# Altium schematic sheet HS40-1177-B09-BUTTON BOARD.SchDoc
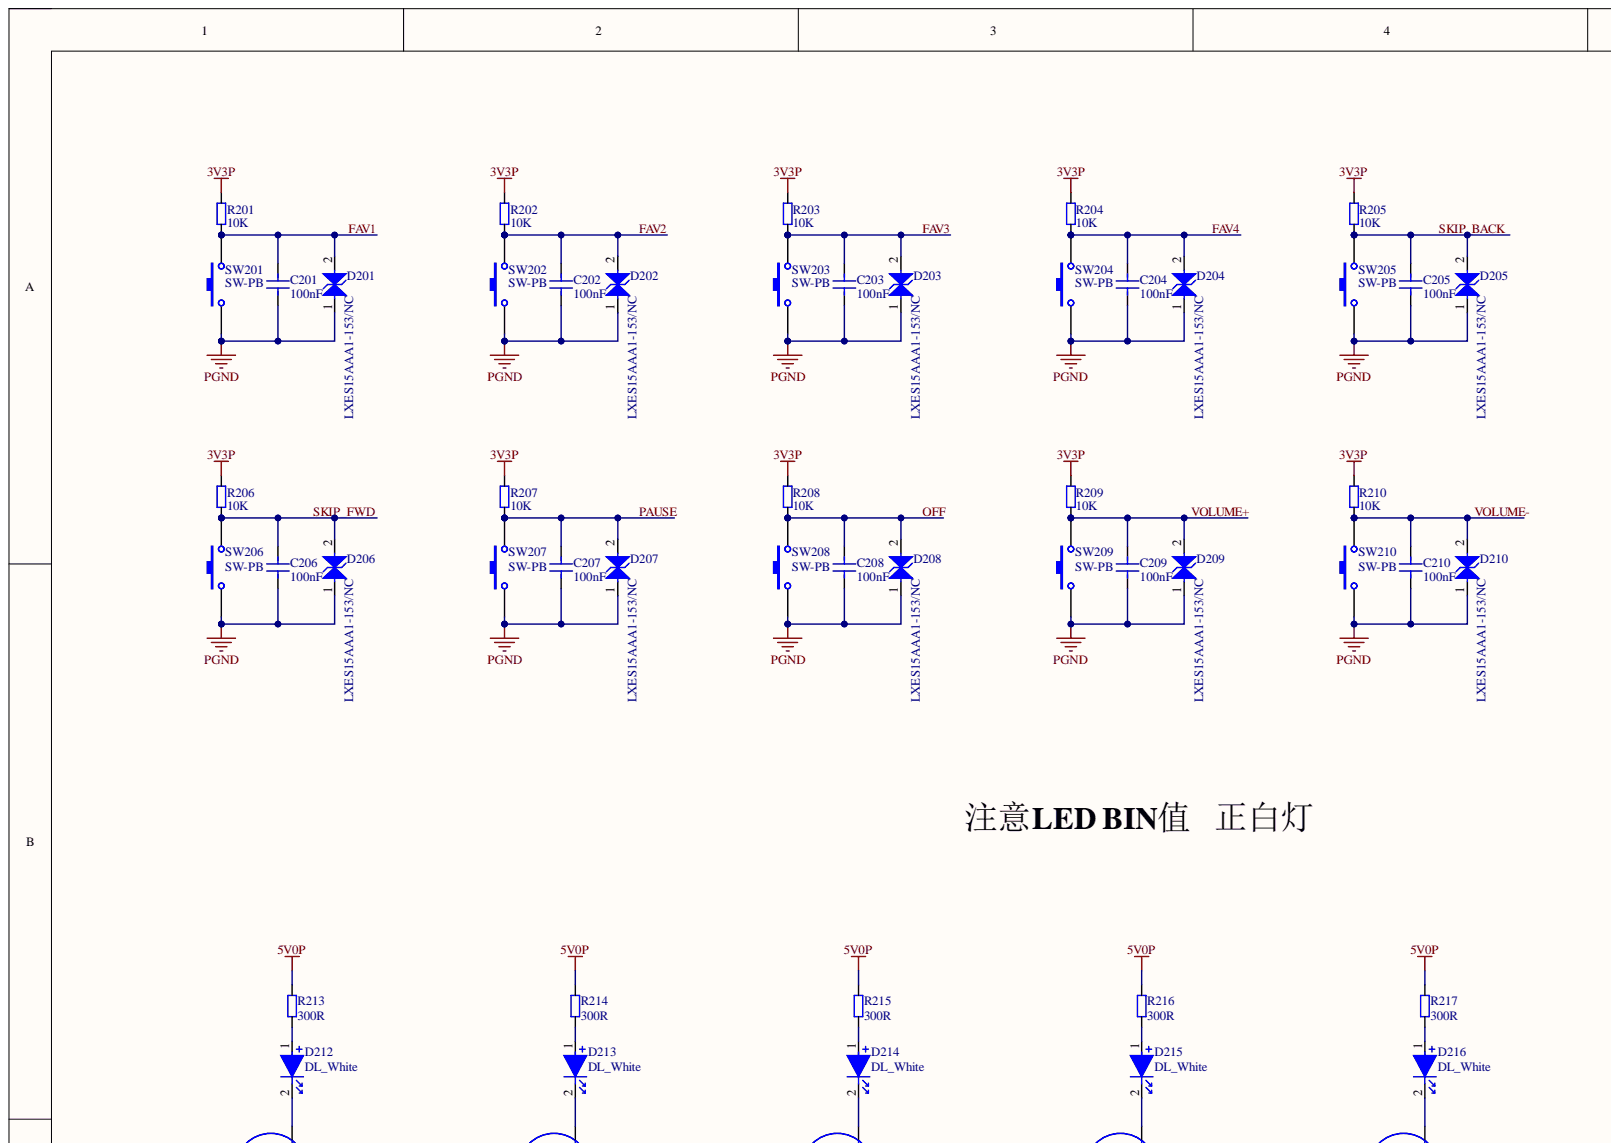
[diagram p0: HS40-1177-B09-BUTTON BOARD.SchDoc, top left]
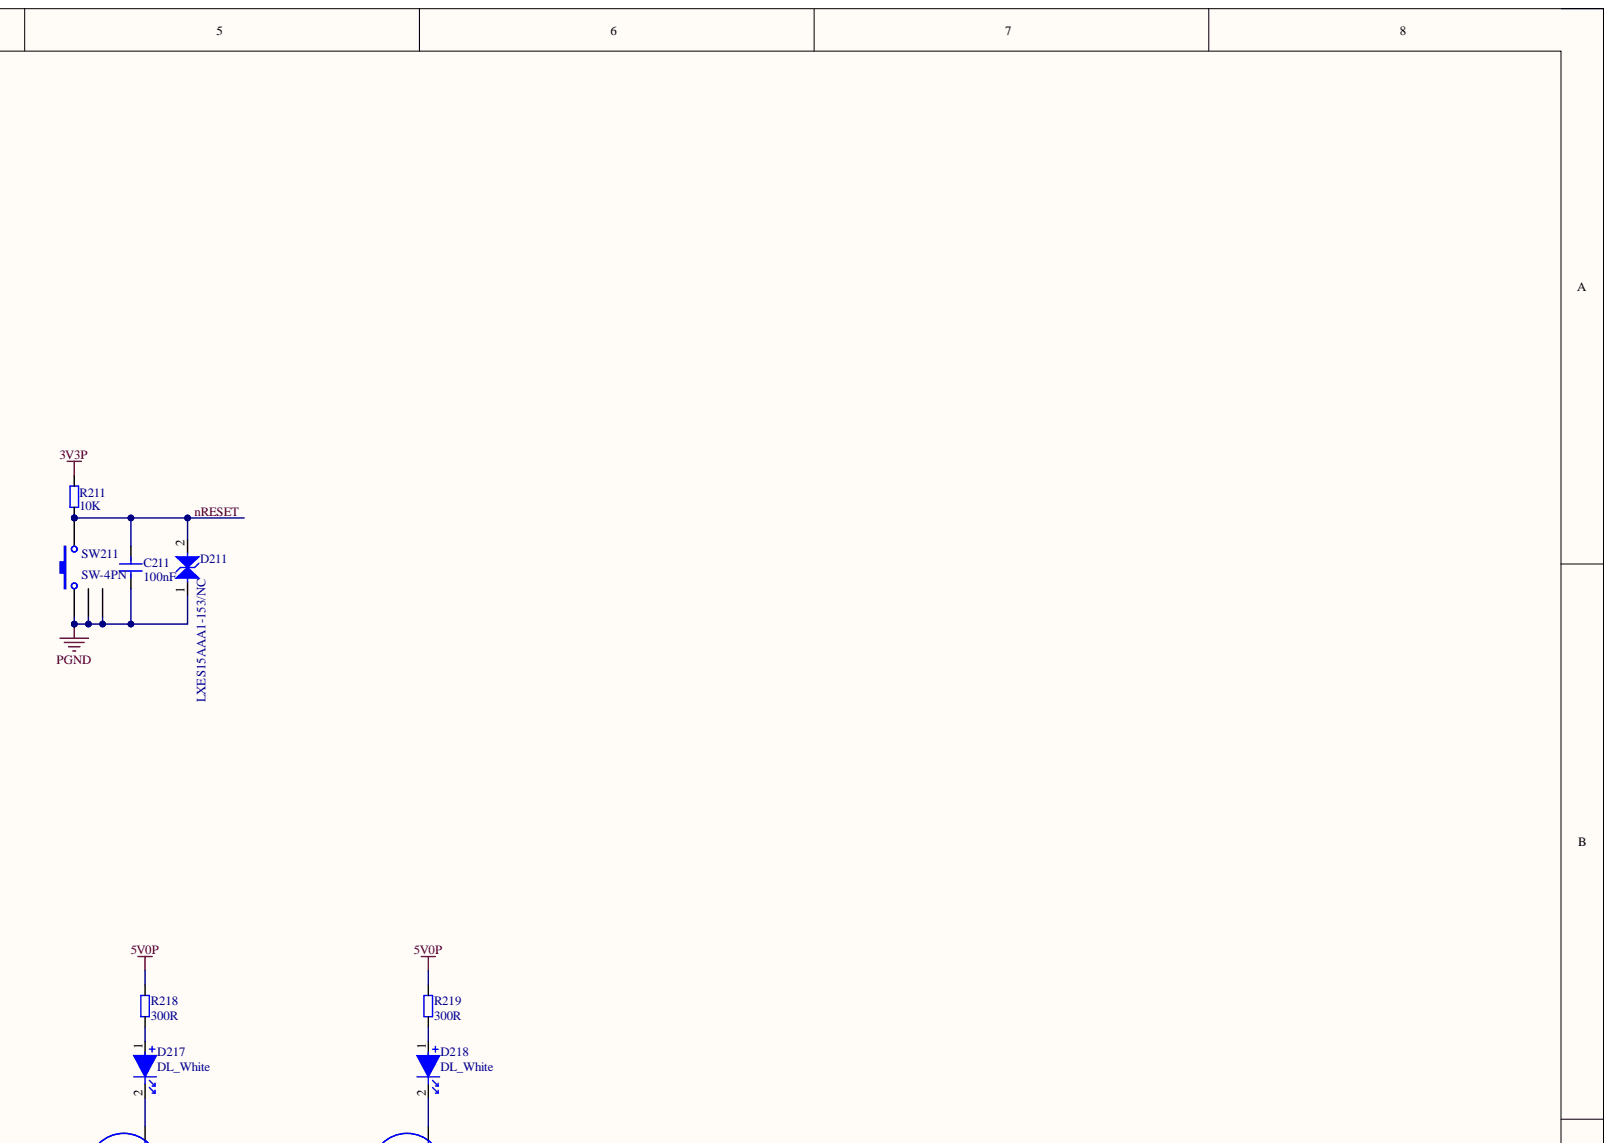
[diagram p1: HS40-1177-B09-BUTTON BOARD.SchDoc, top right]
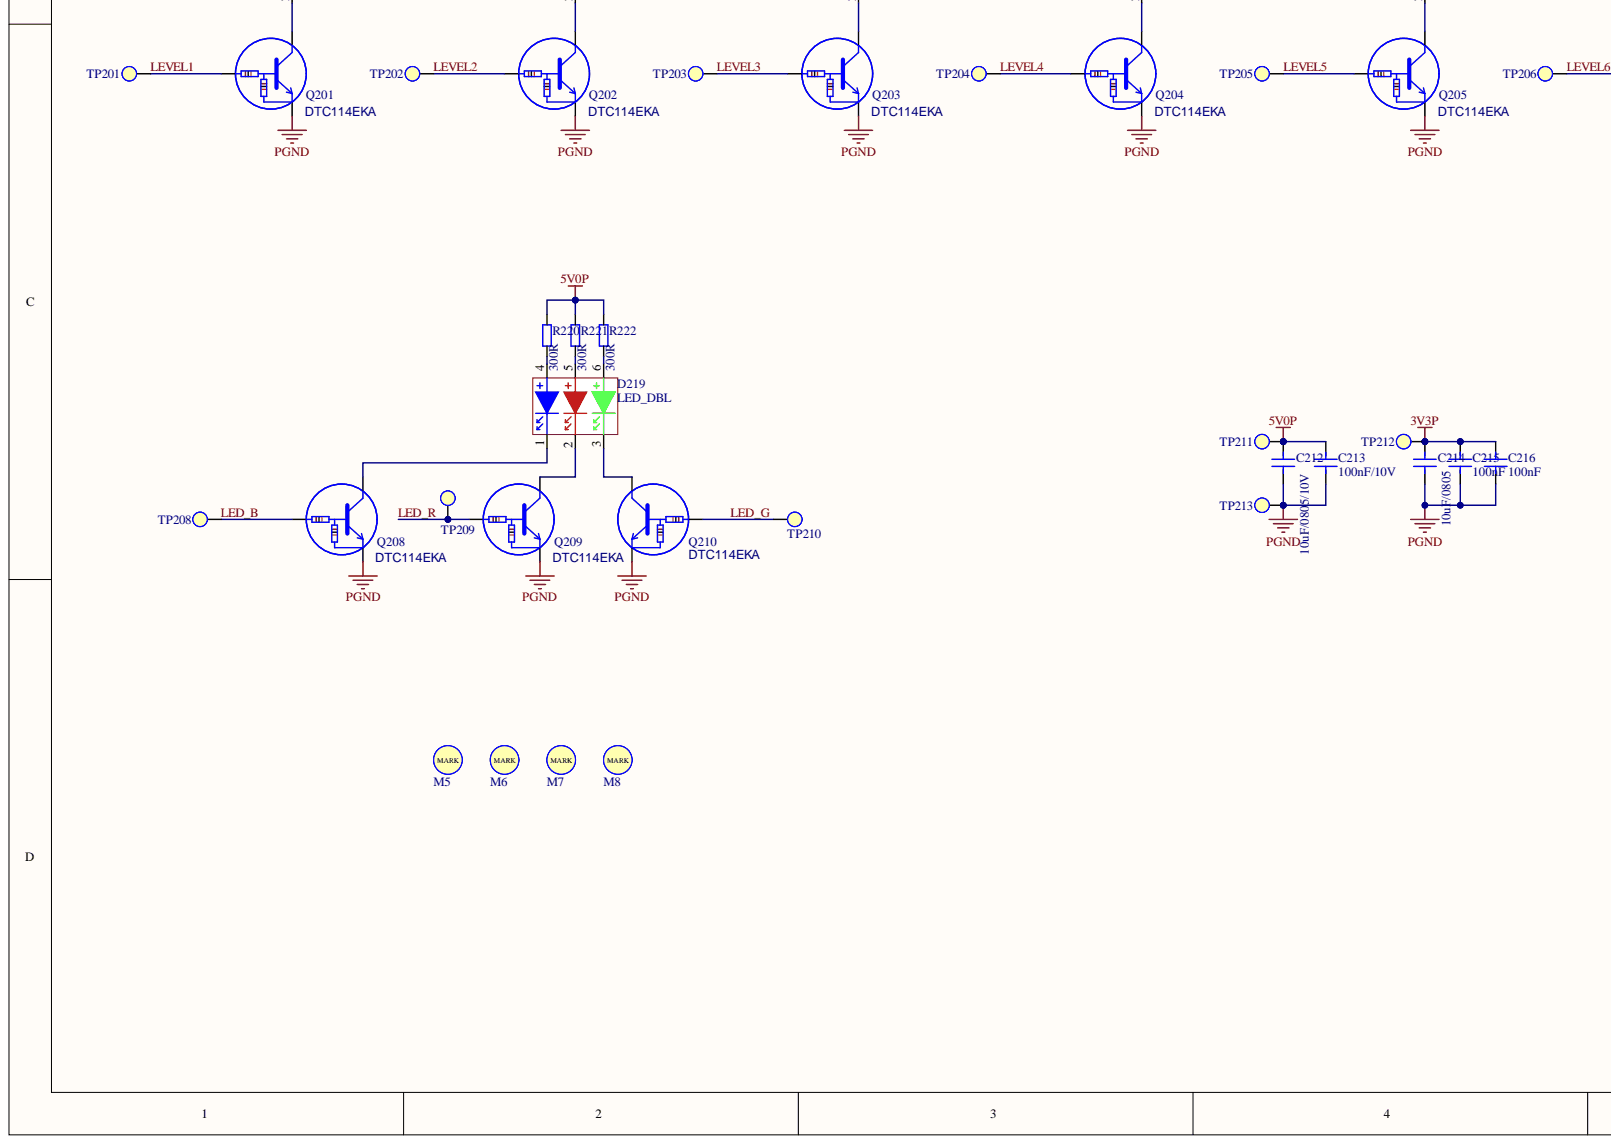
[diagram p2: HS40-1177-B09-BUTTON BOARD.SchDoc, bottom left]
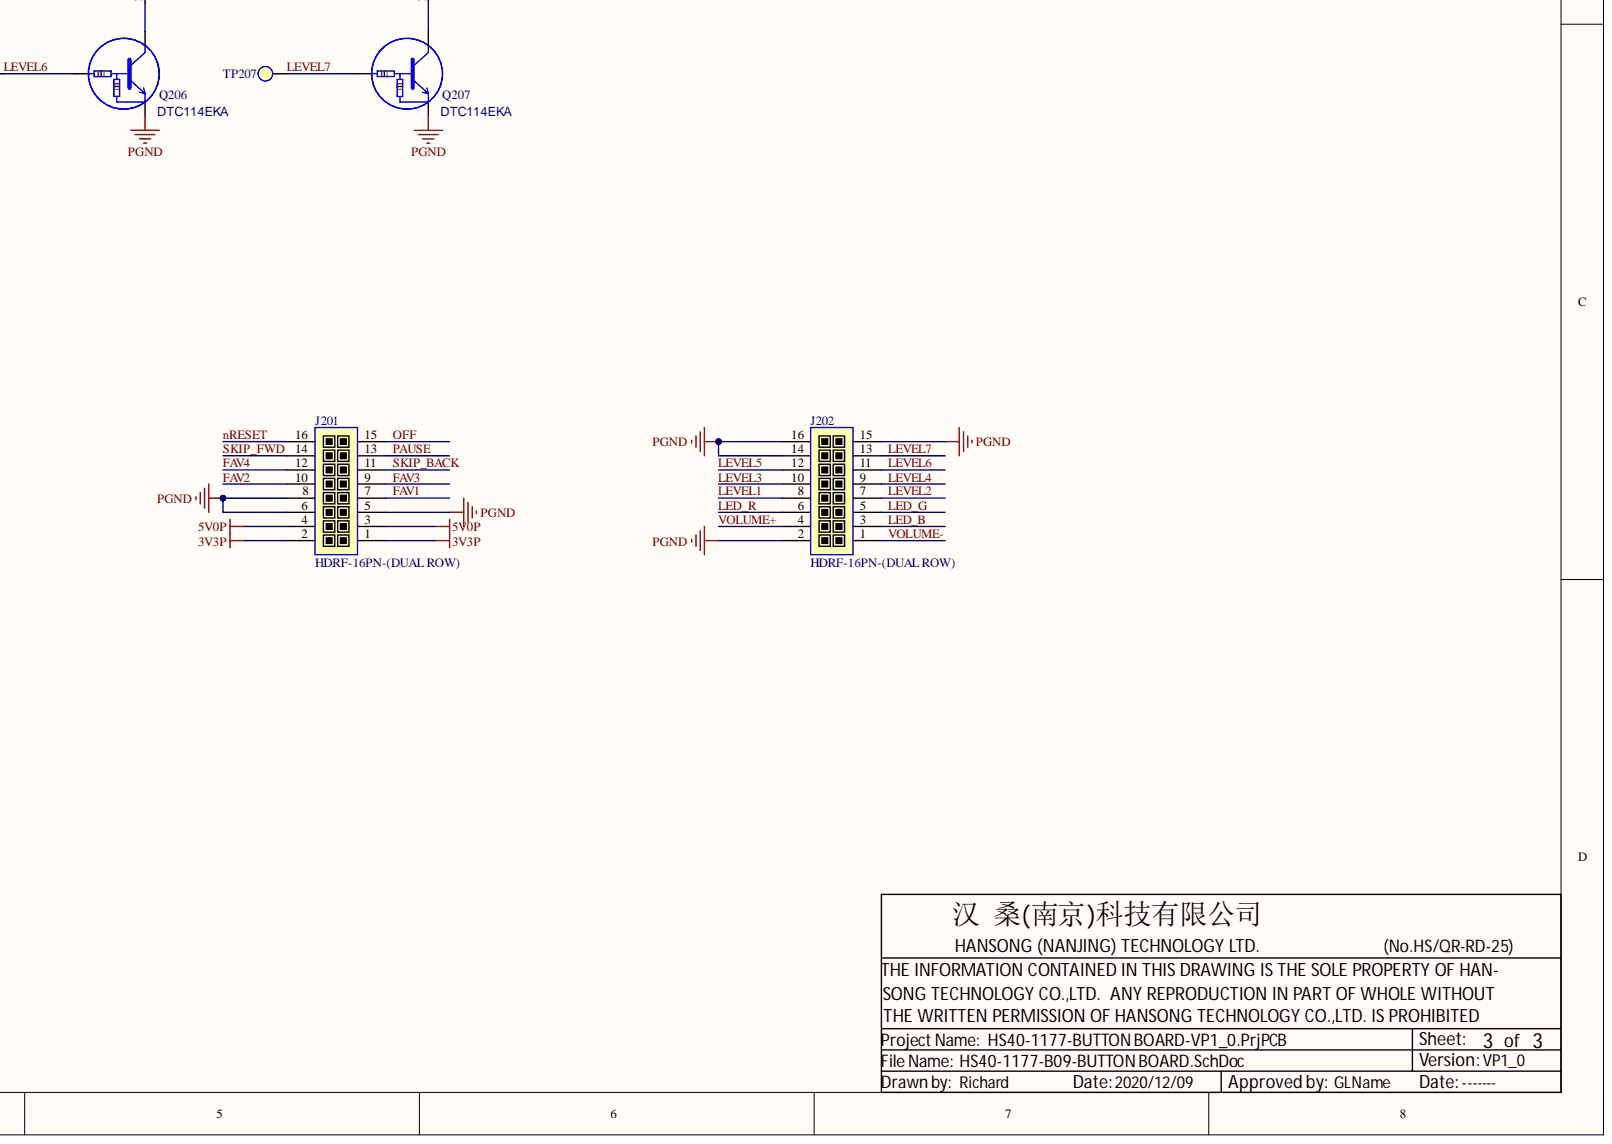
[diagram p3: HS40-1177-B09-BUTTON BOARD.SchDoc, bottom right]

## Parts
C201  100nF  [CC-0402]
C202  100nF  [CC-0402]
C203  100nF  [CC-0402]
C204  100nF  [CC-0402]
C205  100nF  [CC-0402]
C206  100nF  [CC-0402]
C207  100nF  [CC-0402]
C208  100nF  [CC-0402]
C209  100nF  [CC-0402]
C210  100nF  [CC-0402]
C211  100nF  [CC-0402]
C212  10uF/0805/10V  [CC-0805]
C213  100nF/10V  [CC-0402]
C214  10uF/0805  [CC-0805]
C215  100nF  [CC-0402]
C216  100nF  [CC-0402]
D201  LXES15AAA1-153/NC  [DZ-2PN-(0402,TVS)]
D202  LXES15AAA1-153/NC  [DZ-2PN-(0402,TVS)]
D203  LXES15AAA1-153/NC  [DZ-2PN-(0402,TVS)]
D204  LXES15AAA1-153/NC  [DZ-2PN-(0402,TVS)]
D205  LXES15AAA1-153/NC  [DZ-2PN-(0402,TVS)]
D206  LXES15AAA1-153/NC  [DZ-2PN-(0402,TVS)]
D207  LXES15AAA1-153/NC  [DZ-2PN-(0402,TVS)]
D208  LXES15AAA1-153/NC  [DZ-2PN-(0402,TVS)]
D209  LXES15AAA1-153/NC  [DZ-2PN-(0402,TVS)]
D210  LXES15AAA1-153/NC  [DZ-2PN-(0402,TVS)]
D211  LXES15AAA1-153/NC  [DZ-2PN-(0402,TVS)]
D212  DL_White  [DL-2PN-1.6X0.8X0.6-(0603)]
D213  DL_White  [DL-2PN-1.6X0.8X0.6-(0603)]
D214  DL_White  [DL-2PN-1.6X0.8X0.6-(0603)]
D215  DL_White  [DL-2PN-1.6X0.8X0.6-(0603)]
D216  DL_White  [DL-2PN-1.6X0.8X0.6-(0603)]
D217  DL_White  [DL-2PN-1.6X0.8X0.6-(0603)]
D218  DL_White  [DL-2PN-1.6X0.8X0.6-(0603)]
D219  LED_DBL  [DL-6PN-1.6X1.6X0.4-(THREE COLOR)]
J201  HDRF-16PN-(DUAL ROW)  [HDRF-16PN-1.27PH-10.51X3.1X4.6-(POST,DUAL ROW,43-1-000403)]
J202  HDRF-16PN-(DUAL ROW)  [HDRF-16PN-1.27PH-10.51X3.1X4.6-(POST,DUAL ROW,43-1-000403)]
M5  MARK  [MARK-(ROUND,MULTI  LAYER)]
M6  MARK  [MARK-(ROUND,MULTI  LAYER)]
M7  MARK  [MARK-(ROUND,MULTI  LAYER)]
M8  MARK  [MARK-(ROUND,MULTI  LAYER)]
Q201  DTC114EKA  [TS-3PN-2.9X1.3X1.1-(SOT23,B,C,E)]
Q202  DTC114EKA  [TS-3PN-2.9X1.3X1.1-(SOT23,B,C,E)]
Q203  DTC114EKA  [TS-3PN-2.9X1.3X1.1-(SOT23,B,C,E)]
Q204  DTC114EKA  [TS-3PN-2.9X1.3X1.1-(SOT23,B,C,E)]
Q205  DTC114EKA  [TS-3PN-2.9X1.3X1.1-(SOT23,B,C,E)]
Q206  DTC114EKA  [TS-3PN-2.9X1.3X1.1-(SOT23,B,C,E)]
Q207  DTC114EKA  [TS-3PN-2.9X1.3X1.1-(SOT23,B,C,E)]
Q208  DTC114EKA  [TS-3PN-2.9X1.3X1.1-(SOT23,B,C,E)]
Q209  DTC114EKA  [TS-3PN-2.9X1.3X1.1-(SOT23,B,C,E)]
Q210  DTC114EKA  [TS-3PN-2.9X1.3X1.1-(SOT23,B,C,E)]
R201  10K  [RR-0402]
R202  10K  [RR-0402]
R203  10K  [RR-0402]
R204  10K  [RR-0402]
R205  10K  [RR-0402]
R206  10K  [RR-0402]
R207  10K  [RR-0402]
R208  10K  [RR-0402]
R209  10K  [RR-0402]
R210  10K  [RR-0402]
R211  10K  [RR-0402]
R213  300R  [RR-0603]
R214  300R  [RR-0603]
R215  300R  [RR-0603]
R216  300R  [RR-0603]
R217  300R  [RR-0603]
R218  300R  [RR-0603]
R219  300R  [RR-0603]
R220  300R  [RR-0603]
R221  300R  [RR-0603]
R222  300R  [RR-0603]
SW201  SW-PB  [SW-2PN-3.9X2.9XH-(IT-1100E-1160,25-1-000009,NO DATASHEET)]
SW202  SW-PB  [SW-2PN-3.9X2.9XH-(IT-1100E-1160,25-1-000009,NO DATASHEET)]
SW203  SW-PB  [SW-2PN-3.9X2.9XH-(IT-1100E-1160,25-1-000009,NO DATASHEET)]
SW204  SW-PB  [SW-2PN-3.9X2.9XH-(IT-1100E-1160,25-1-000009,NO DATASHEET)]
SW205  SW-PB  [SW-2PN-3.9X2.9XH-(IT-1100E-1160,25-1-000009,NO DATASHEET)]
SW206  SW-PB  [SW-2PN-3.9X2.9XH-(IT-1100E-1160,25-1-000009,NO DATASHEET)]
SW207  SW-PB  [SW-2PN-3.9X2.9XH-(IT-1100E-1160,25-1-000009,NO DATASHEET)]
SW208  SW-PB  [SW-2PN-3.9X2.9XH-(IT-1100E-1160,25-1-000009,NO DATASHEET)]
SW209  SW-PB  [SW-2PN-3.9X2.9XH-(IT-1100E-1160,25-1-000009,NO DATASHEET)]
SW210  SW-PB  [SW-2PN-3.9X2.9XH-(IT-1100E-1160,25-1-000009,NO DATASHEET)]
SW211  SW-4PN  [SW-4PN-7.3X6X3.95-(TAC-32N-V-T/R,25-1-000015)]
TP201  TP  [TP-D0.8]
TP202  TP  [TP-D0.8]
TP203  TP  [TP-D0.8]
TP204  TP  [TP-D0.8]
TP205  TP  [TP-D0.8]
TP206  TP  [TP-D0.8]
TP207  TP  [TP-D0.8]
TP208  TP  [TP-D0.8]
TP209  TP  [TP-D0.8]
TP210  TP  [TP-D0.8]
TP211  TP  [TP-D0.8]
TP212  TP  [TP-D0.8]
TP213  TP  [TP-D0.8]

## Nets
3V3P: C214.2 C215.2 C216.2 J201.1 J201.2 R201.2 R202.2 R203.2 R204.2 R205.2 R206.2 R207.2 R208.2 R209.2 R210.2 R211.2 TP212.1
5V0P: C212.2 C213.2 J201.3 J201.4 R213.2 R214.2 R215.2 R216.2 R217.2 R218.2 R219.2 R220.2 R221.2 R222.2 TP211.1
PGND: C201.1 C202.1 C203.1 C204.1 C205.1 C206.1 C207.1 C208.1 C209.1 C210.1 C211.1 C212.1 C213.1 C214.1 C215.1 C216.1 D201.1 D202.1 D203.1 D204.1 D205.1 D206.1 D207.1 D208.1 D209.1 D210.1 D211.1 J201.5 J201.6 J201.8 J202.2 J202.14 J202.15 J202.16 Q201.e Q202.e Q203.e Q204.e Q205.e Q206.e Q207.e Q208.e Q209.e Q210.e SW201.1 SW202.1 SW203.1 SW204.1 SW205.1 SW206.1 SW207.1 SW208.1 SW209.1 SW210.1 SW211.1 SW211.3 SW211.4 TP213.1
FAV1: C201.2 D201.2 J201.7 R201.1 SW201.2
FAV2: C202.2 D202.2 J201.10 R202.1 SW202.2
FAV3: C203.2 D203.2 J201.9 R203.1 SW203.2
FAV4: C204.2 D204.2 J201.12 R204.1 SW204.2
LED_B: J202.3 Q208.b TP208.1
LED_G: J202.5 Q210.b TP210.1
LED_R: J202.6 Q209.b TP209.1
LEVEL1: J202.8 Q201.b TP201.1
LEVEL2: J202.7 Q202.b TP202.1
LEVEL3: J202.10 Q203.b TP203.1
LEVEL4: J202.9 Q204.b TP204.1
LEVEL5: J202.12 Q205.b TP205.1
LEVEL6: J202.11 Q206.b TP206.1
LEVEL7: J202.13 Q207.b TP207.1
nRESET: C211.2 D211.2 J201.16 R211.1 SW211.2
OFF: C208.2 D208.2 J201.15 R208.1 SW208.2
PAUSE: C207.2 D207.2 J201.13 R207.1 SW207.2
SKIP_BACK: C205.2 D205.2 J201.11 R205.1 SW205.2
SKIP_FWD: C206.2 D206.2 J201.14 R206.1 SW206.2
VOLUME+: C209.2 D209.2 J202.4 R209.1 SW209.2
VOLUME-: C210.2 D210.2 J202.1 R210.1 SW210.2
NetD212_1: D212.1 R213.1
NetD212_2: D212.2 Q201.c
NetD213_1: D213.1 R214.1
NetD213_2: D213.2 Q202.c
NetD214_1: D214.1 R215.1
NetD214_2: D214.2 Q203.c
NetD215_1: D215.1 R216.1
NetD215_2: D215.2 Q204.c
NetD216_1: D216.1 R217.1
NetD216_2: D216.2 Q205.c
NetD217_1: D217.1 R218.1
NetD217_2: D217.2 Q206.c
NetD218_1: D218.1 R219.1
NetD218_2: D218.2 Q207.c
NetD219_1: D219.1 Q208.c
NetD219_2: D219.2 Q209.c
NetD219_3: D219.3 Q210.c
NetD219_4: D219.4 R220.1
NetD219_5: D219.5 R221.1
NetD219_6: D219.6 R222.1

## Library data of the parts
C201-C211 C213 C215 C216 = CC: Capacitor; 新品号: 23-1-001652; Component Kind: Standard; Library Name: Hansong-Standard SCH Library.SchLib
C212 C214 = CC: Capacitor; 新品号: 23-1-000039; Component Kind: Standard; Library Name: Hansong-Standard SCH Library.SchLib
D201-D211 = DZ-(TVS): Zener Diode; 新品号: 12-1-000545; Component Kind: Standard; Library Name: Hansong-Standard SCH Library.SchLib
D212-D218 = DL: Light Emitting Diode; 新品号: 12-1-000249; Component Kind: Standard; Library Name: Hansong-Standard SCH Library.SchLib
D219 = LED_DBL: 新品号: 12-1-000272; Component Kind: Standard; Library Name: HS40-1177-BOARD-V1.0.SCHLIB
J201 J202 = HDRF-16PN-(DUAL ROW): Female Header; 新品号: 43-1-000403; Component Kind: Standard; Library Name: Hansong-Standard SCH Library.SchLib
Q201-Q210 = DTC114EKA: 31-00114-05; Text Field1: 数字三极管; Text Field2: 310011405; Text Field3: DTC114, SOT23, Tape; Text Field4: DTC114EKA, Tape; Text Field5: ROHM; 新品号: 13-1-000005; Component Kind: Standard
R201-R211 = RR: Resistor; 新品号: 21-1-000151; Component Kind: Standard; Library Name: Hansong-Standard SCH Library.SchLib
R213-R222 = RR: Resistor; 新品号: 21-1-000920; Component Kind: Standard; Library Name: Hansong-Standard SCH Library.SchLib
SW201-SW210 = SW-PB: Switch; 新品号: 25-1-000009; Component Kind: Standard; LatestRevisionDate: 17-Jul-2002; LatestRevisionNote: Re-released for DXP Platform.; Library Name: HS40-1177-BOARD-V1.0.SCHLIB; PackageDescription: Switch; 2 Leads; PackageReference: SPST-2; Published: 24-Mar-1999; Publisher: Altium Limited
SW211 = SW-4PN: SWITCH; 新品号: 25-1-000015; Component Kind: Standard; Library Name: HS40-1177-BOARD-V1.0.SCHLIB
TP201-TP213 = TP: Test Point; Component Kind: Standard; Library Name: Hansong-Standard SCH Library.SchLib
M5-M8 = MARK: Component Kind: Standard; Description: MARK; Library Name: Hansong-Standard SCH Library.SchLib
